# Altium schematic sheet Power.SchDoc
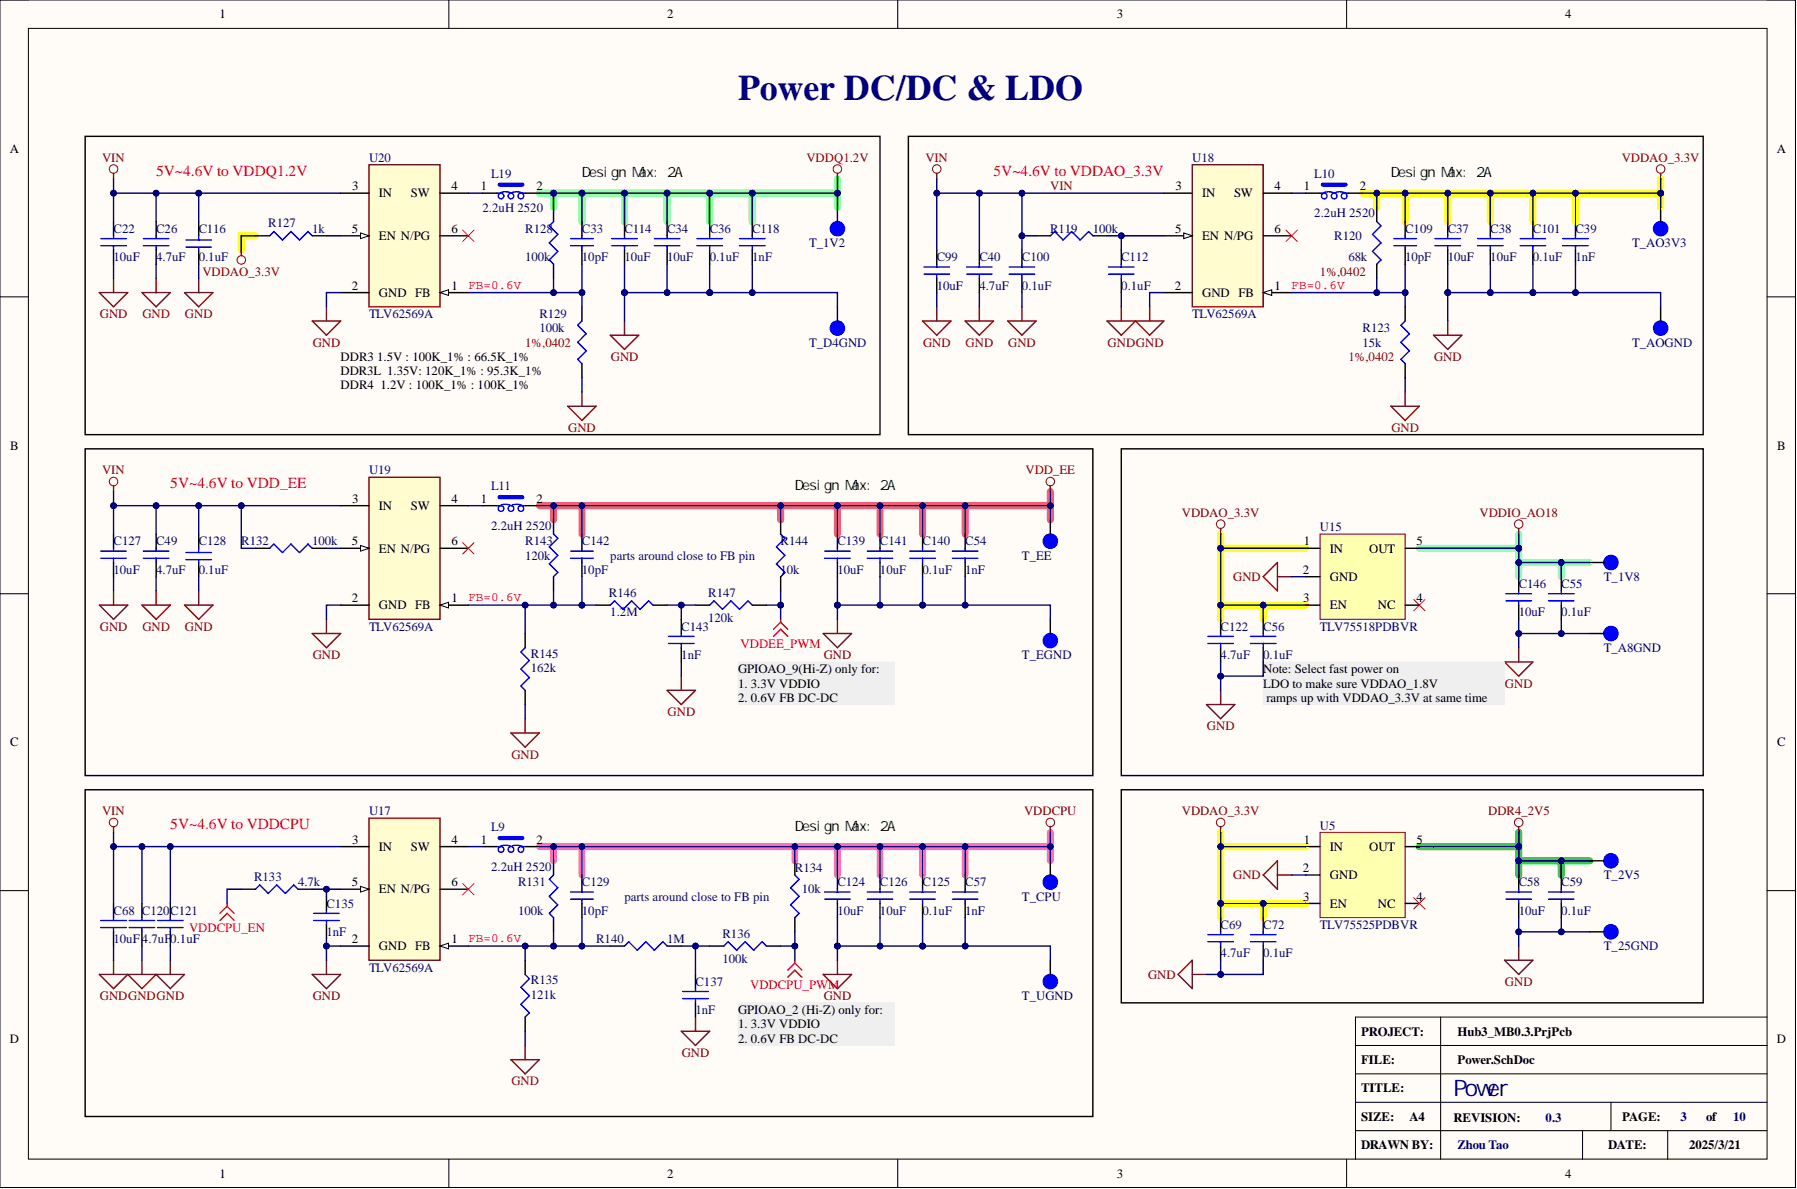
[diagram p0: Power.SchDoc]

## Parts
C22  10uF  [C0603]
C26  4.7uF  [C0603]
C33  10pF  [C0402]
C34  10uF  [C0603]
C36  0.1uF  [C0402]
C37  10uF  [C0603]
C38  10uF  [C0603]
C39  1nF  [C0402]
C40  4.7uF  [C0603]
C49  4.7uF  [C0603]
C54  1nF  [C0402]
C55  0.1uF  [C0402]
C56  0.1uF  [C0402]
C57  1nF  [C0402]
C58  10uF  [C0603]
C59  0.1uF  [C0402]
C68  10uF  [C0603]
C69  4.7uF  [C0603]
C72  0.1uF  [C0402]
C99  10uF  [C0603]
C100  0.1uF  [C0402]
C101  0.1uF  [C0402]
C109  10pF  [C0402]
C112  0.1uF  [C0402]
C114  10uF  [C0603]
C116  0.1uF  [C0402]
C118  1nF  [C0402]
C120  4.7uF  [C0603]
C121  0.1uF  [C0402]
C122  4.7uF  [C0603]
C124  10uF  [C0603]
C125  0.1uF  [C0402]
C126  10uF  [C0603]
C127  10uF  [C0603]
C128  0.1uF  [C0402]
C129  10pF  [C0402]
C135  1nF  [C0402]
C137  1nF  [C0402]
C139  10uF  [C0603]
C140  0.1uF  [C0402]
C141  10uF  [C0603]
C142  10pF  [C0402]
C143  1nF  [C0402]
C146  10uF  [C0603]
L9  2.2uH 2520  [L2520]
L10  2.2uH 2520  [L2520]
L11  2.2uH 2520  [L2520]
L19  2.2uH 2520  [L2520]
R119  value 100k  [R0402]  (hidden comment: RES_100k_0402_1%)
R120  68k  [R0402]
R123  15k  [R0402]
R127  1k  [R0201]
R128  value 100k  [R0402]  (hidden comment: RES_100k_0402_1%)
R129  value 100k  [R0402]  (hidden comment: RES_100k_0402_1%)
R131  value 100k  [R0402]  (hidden comment: RES_100k_0402_1%)
R132  value 100k  [R0402]  (hidden comment: RES_100k_0402_1%)
R133  value 4.7k  [R0402]  (hidden comment: RES_4.7k_0402_1%)
R134  value 10k  [R0402]  (hidden comment: RES_10k_0402_1%)
R135  value 121k  [R0402]  (hidden comment: RES_121k_0402_1%)
R136  value 100k  [R0402]  (hidden comment: RES_100k_0402_1%)
R140  1M  [R0402]
R143  value 120k  [R0402]  (hidden comment: RES_120k_0402_1%)
R144  value 10k  [R0402]  (hidden comment: RES_10k_0402_1%)
R145  value 162k  [R0402]  (hidden comment: RES_162k_0402_1%)
R146  1.2M  [R0402]
R147  value 120k  [R0402]  (hidden comment: RES_120k_0402_1%)
T_1V2  T_POINT_D1.2mm  [TP_D1.2mm]  (value not drawn)  (no BOM)
T_1V8  T_POINT_D1.2mm  [TP_D1.2mm]  (value not drawn)  (no BOM)
T_2V5  T_POINT_D1.2mm  [TP_D1.2mm]  (value not drawn)  (no BOM)
T_25GND  T_POINT_D1.2mm  [TP_D1.2mm]  (value not drawn)  (no BOM)
T_A8GND  T_POINT_D1.2mm  [TP_D1.2mm]  (value not drawn)  (no BOM)
T_AO3V3  T_POINT_D1.2mm  [TP_D1.2mm]  (value not drawn)  (no BOM)
T_AOGND  T_POINT_D1.2mm  [TP_D1.2mm]  (value not drawn)  (no BOM)
T_CPU  T_POINT_D1.2mm  [TP_D1.2mm]  (value not drawn)  (no BOM)
T_D4GND  T_POINT_D1.2mm  [TP_D1.2mm]  (value not drawn)  (no BOM)
T_EE  T_POINT_D1.2mm  [TP_D1.2mm]  (value not drawn)  (no BOM)
T_EGND  T_POINT_D1.2mm  [TP_D1.2mm]  (value not drawn)  (no BOM)
T_UGND  T_POINT_D1.2mm  [TP_D1.2mm]  (value not drawn)  (no BOM)
U5  TLV75525PDBVR  [SOT23-5_7533]
U15  TLV75518PDBVR  [SOT23-5_7533]
U17  TLV62569A  [SOT563]
U18  TLV62569A  [SOT563]
U19  TLV62569A  [SOT563]
U20  TLV62569A  [SOT563]

## Nets
DDR4_2V5: C58.1 C59.1 T_2V5.1 U5.5(OUT)
GND: C22.2 C26.2 C34.2 C36.2 C37.2 C38.2 C39.2 C40.2 C49.2 C54.2 C55.2 C56.2 C57.2 C58.2 C59.2 C68.2 C69.2 C72.2 C99.2 C100.2 C101.2 C112.2 C114.2 C116.1 C118.2 C120.2 C121.2 C122.2 C124.2 C125.2 C126.2 C127.2 C128.1 C135.2 C137.2 C139.2 C140.2 C141.2 C143.2 C146.2 R123.1 R129.1 R135.2 R145.1 T_25GND.1 T_A8GND.1 T_AOGND.1 T_D4GND.1 T_EGND.1 T_UGND.1 U5.2(GND) U15.2(GND) U17.2(GND) U18.2(GND) U19.2(GND) U20.2(GND)
VDD_EE: C54.1 C139.1 C140.1 C141.1 C142.1 L11.2 R143.1 R144.1 T_EE.1
VDDAO_3.3V: C37.1 C38.1 C39.1 C56.1 C69.1 C72.1 C101.1 C109.1 C122.1 L10.2 R120.1 R127.1 T_AO3V3.1 U5.1(IN) U5.3(EN) U15.1(IN) U15.3(EN)
VDDCPU: C57.1 C124.1 C125.1 C126.1 C129.1 L9.2 R131.2 R134.1 T_CPU.1
VDDCPU_EN: R133.1
VDDCPU_PWM: R134.2 R136.2
VDDEE_PWM: R144.2 R147.2
VDDIO_AO18: C55.1 C146.1 T_1V8.1 U15.5(OUT)
VDDQ1.2V: C33.1 C34.1 C36.1 C114.1 C118.1 L19.2 R128.2 T_1V2.1
VIN: C22.1 C26.1 C40.1 C49.1 C68.1 C99.1 C100.1 C116.2 C120.1 C121.1 C127.1 C128.2 R119.1 R132.1 U17.3(IN) U18.3(IN) U19.3(IN) U20.3(IN)
NetC33_2: C33.2 R128.1 R129.2 U20.1(FB)
NetC109_2: C109.2 R120.2 R123.2 U18.1(FB)
NetC112_1: C112.1 R119.2 U18.5(EN)
NetC129_2: C129.2 R131.1 R135.1 R140.1 U17.1(FB)
NetC135_1: C135.1 R133.2 U17.5(EN)
NetC137_1: C137.1 R136.1 R140.2
NetC142_2: C142.2 R143.2 R145.2 R146.1 U19.1(FB)
NetC143_1: C143.1 R146.2 R147.1
NetL9_1: L9.1 U17.4(SW)
NetL10_1: L10.1 U18.4(SW)
NetL11_1: L11.1 U19.4(SW)
NetL19_1: L19.1 U20.4(SW)
NetR127_2: R127.2 U20.5(EN)
NetR132_2: R132.2 U19.5(EN)

Not connected: U5 4(NC); U15 4(NC); U17 6(N/PG); U18 6(N/PG); U19 6(N/PG); U20 6(N/PG)

## Library data of the parts
C22 C34 C37 C38 C58 C68 C99 C114 C124 C126 C127 C139 C141 C146 = Cap_10uF_0603_10%_10V: Manufacturer: Samsung; Part Number: CL10A106KP8NNNC; Des: 10uF,0603,X5R, 10%, 10V; Component Kind: Standard; value: 10uF; Library Name: Lib_sch_Hubv3_2332.SchLib
C26 C40 C49 C69 C120 C122 = Cap_4.7uF_0603_X5R_10%_10V: Manufacturer: Yageo; Part Number: CC0603KRX5R6BB475; Des: 4.7uF,C0603, 10V, X5R,10%; Component Kind: Standard; value: 4.7uF; Library Name: Lib_sch_Hubv3_2332.SchLib
C33 C109 C129 C142 = Cap_10pF_+/-1%_0402_50V_C0G: Manufacturer: Yageo; Part Number: CC0402FRNPO9BN100; Des: 10pF ±1% 50V, C0G, NP0 0402; Component Kind: Standard; value: 10pF; Library Name: Lib_sch_Hubv3_2332.SchLib
C36 C55 C56 C59 C72 C100 C101 C112 C116 C121 C125 C128 C140 = Cap_0.1uF_0402_10%_16V: Manufacturer: VIIYONG; Part Number: V104K0402X7R160NBT; Des: 0.1uF,0402,16V,X7R,10%; Component Kind: Standard; value: 0.1uF; Library Name: Lib_sch_Hubv3_2332.SchLib
C39 C54 C57 C118 C135 C137 C143 = Cap_1nF_0402_50V: Manufacturer: EYANG; Part Number: C0402X7R102K500NTB; Des: 1nF,0402,50V,10%,X7R; Component Kind: Standard; value: 1nF; Library Name: Lib_sch_sisv3_2332.SchLib
L9-L11 L19 = Inductor_PWR_2.2uH_2520_SMD: Manufacturer: Sunlord Inc; Part Number: WPN252010H2R2MT; Component Kind: Standard; Des: Inductor_PWR_2.2uH_2520_SMD; value: 2.2uH 2520; Library Name: Lib_sch_spk_V1_2020604.SchLib
R119 R128 R129 R131 R132 R136 = RES_100k_0402_1%: Manufacturer: Yageo; part number: RC0402FR-07100KL; Des: 100k,0402,1%,1/16w; Component Kind: Standard; Library Name: Lib_sch_spk_V0_180613.SchLib
R120 = RES_68k_0402_1%: Manufacturer: Yageo; part number: RC0402FR-0768KL; Des: 68k,0402,1%,1/16w; Component Kind: Standard; value: 68k; Library Name: Lib_sch_spk_V0_181010.SchLib
R123 = RES_15k_0402_1%: Manufacturer: Yageo; part number: RC0402FR-0715KL; Des: 15k,0402,1%,1/16w; Component Kind: Standard; value: 15k; Library Name: Lib_sch_spk_V0_181010.SchLib
R127 = RES_1k_0201_1%: Manufacturer: Yageo; part number: RC0201FR-071KL; Des: 1k,0201,1%,1/20w; Component Kind: Standard; value: 1k; Library Name: Lib_sch_spk_V0_181010.SchLib
R133 = RES_4.7k_0402_1%: Manufacturer: Yageo; part number: C0402FR-074K7L; Des: 4.7k,0402,1%; Component Kind: Standard; Library Name: Lib_sch_spk_V0_180613.SchLib
R134 R144 = RES_10k_0402_1%: Manufacturer: Yageo; part number: RC0402FR-0710KL; Des: 10k,0402,1%; Component Kind: Standard; Library Name: Lib_sch_spk_V0_180613.SchLib
R135 = RES_121k_0402_1%: Manufacturer: Yageo; part number: RC0402FR-07121KL; Des: 121k,0402,1%,1/16W; Component Kind: Standard; Library Name: Lib_sch_spk_V0_180613.SchLib
R140 = RES_1M_0402_1%: Manufacturer: Yageo; part number: RC0402FR-071ML; Des: 1M,0402,1%,1/16w; Component Kind: Standard; value: 1M; Library Name: Lib_sch_spk_V0_180613.SchLib
R143 R147 = RES_120k_0402_1%: Manufacturer: Yageo; part number: RC0402FR-07120KL; Des: 120k,0402,1%,1/16w; Component Kind: Standard; Library Name: Lib_sch_spk_V0_180613.SchLib
R145 = RES_162k_0402_1%: Manufacturer: Yageo; part number: RC0402FR-07162KL; Des: 162k,0402,1%,1/16W; Component Kind: Standard; Library Name: Lib_sch_spk_V0_180613.SchLib
R146 = RES_1.2M_0402_1%: Manufacturer: Yageo; part number: RC0402FR-071M2L; Des: 1.2M,0402,1%,1/16w; Component Kind: Standard; value: 1.2M; Library Name: Lib_sch_spk_V0_180901.SchLib
T_1V2 T_1V8 T_2V5 T_25GND T_A8GND T_AO3V3 T_AOGND T_CPU T_D4GND T_EE T_EGND T_UGND = T_POINT_D1.2mm: Des: circle test pad (Dia=1.2mm ); Library Name: Lib_sch_spk_V0_181010.SchLib
U5 = TLV75525PDBVR: Manufacturer: TI; Des: Vin1.45V-5.5V,fixed 2.5V, 500mA, Iq31uA,) Vd=0.238V@500mA,PSRR=52dB (1kHz ~ 1MHz); value: LDO +2V5; Component Kind: Standard; Part Number: TLV75525PDBVR; Library Name: Lib_sch_Hubv3_2332.SchLib
U15 = TLV75518PDBVR: Manufacturer: TI; Des: Vin1.45V-5.5V,fixed 1.8V, 500mA, Iq31uA,) Vd=0.238V@500mA,PSRR=52dB (1kHz ~ 1MHz); Component Kind: Standard; Part Number: TLV75518PDBVR; Library Name: Lib_sch_Hubv3_2332.SchLib
U17-U20 = TLV62569A: Manufacturer: TI; Part Number: TLV62569ADRLR; Des: sync Buck,2A,Iq35uA,Freq1.5M, force PWM, COT,Vi2.5-5.5v,Vo0.6v,Rds100-60mR; Component Kind: Standard; value: TLV62569A; Library Name: Lib_sch_Hubv3_2332.SchLib
